# Altium schematic sheet ESP_WROOM_32_V2_SCHEMATIC.SchDoc
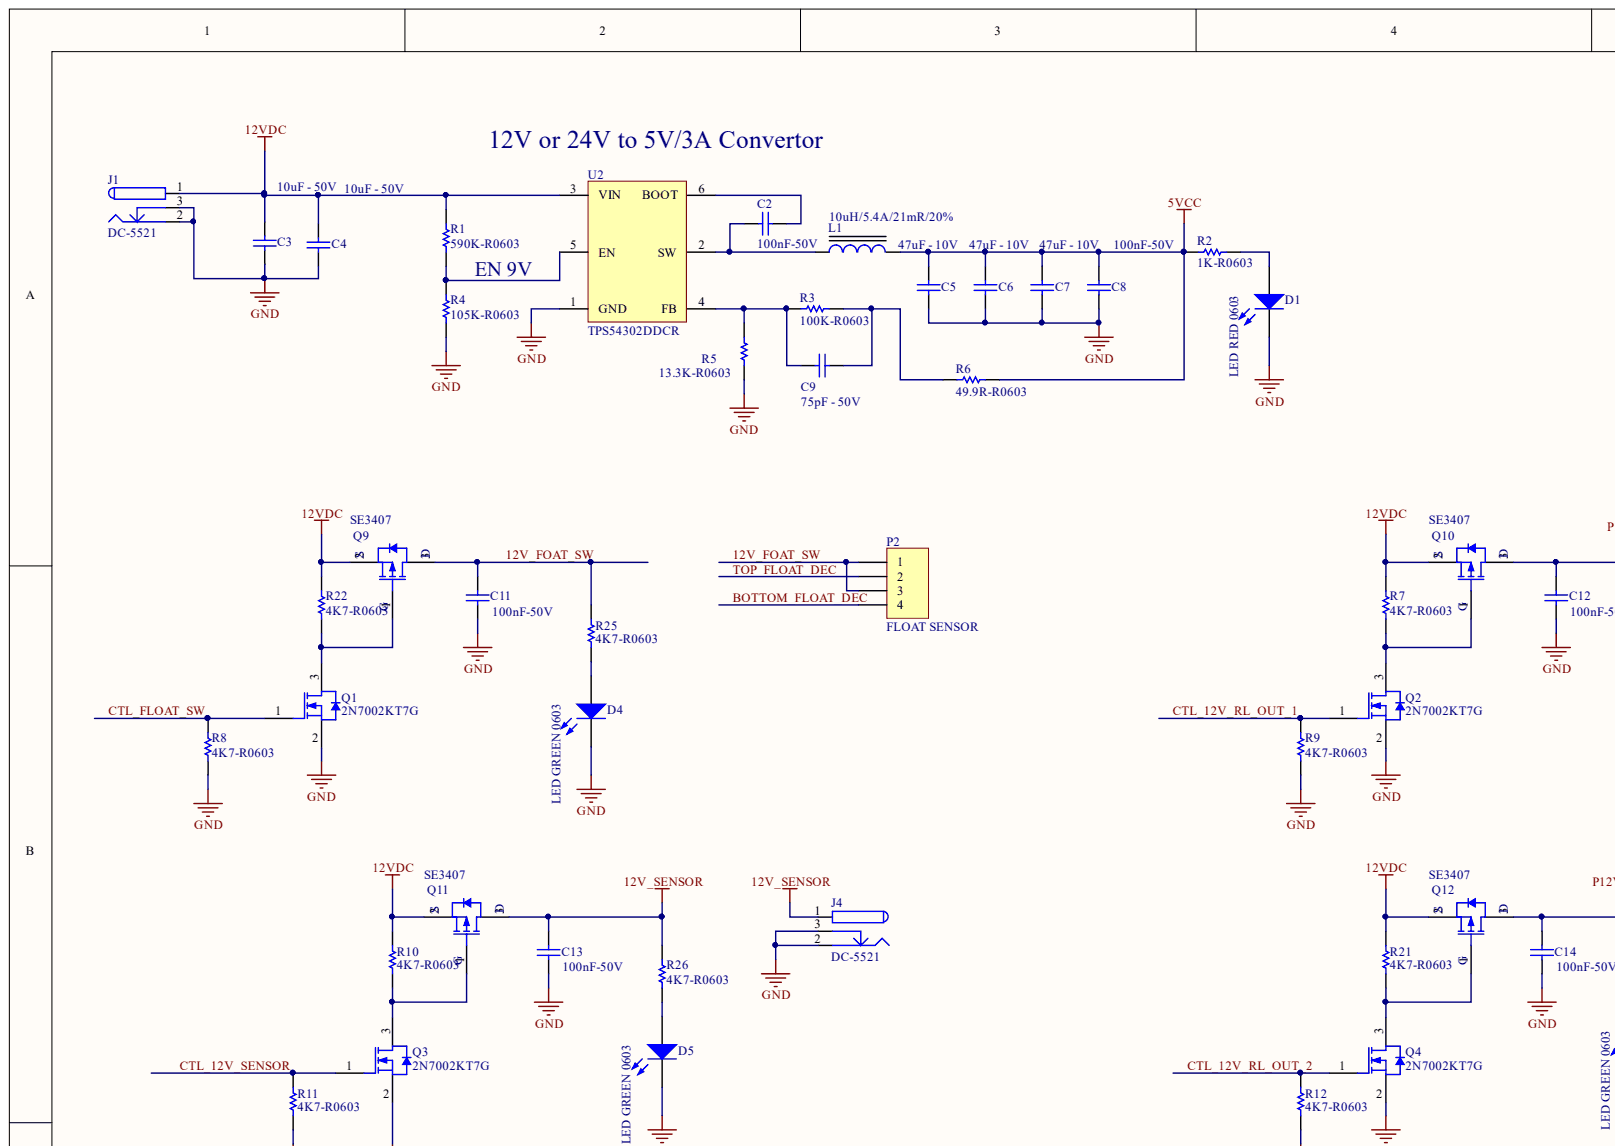
[diagram p0: ESP_WROOM_32_V2_SCHEMATIC.SchDoc, top left]
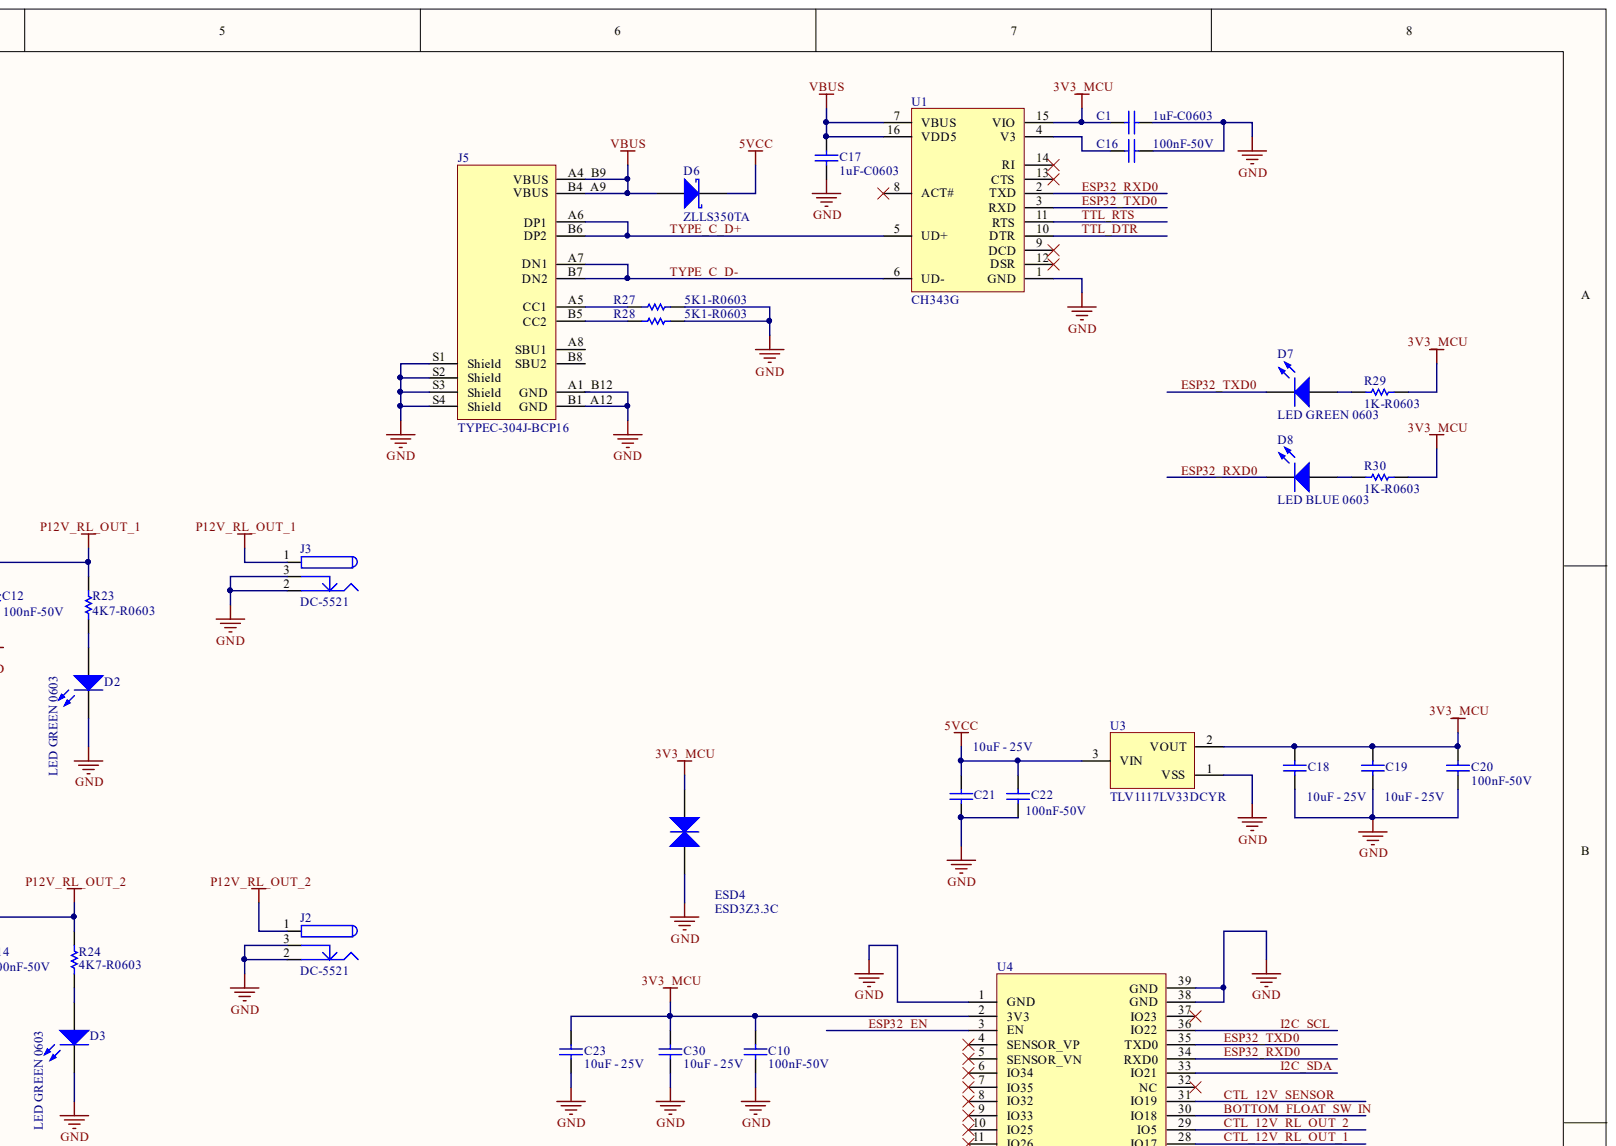
[diagram p1: ESP_WROOM_32_V2_SCHEMATIC.SchDoc, top right]
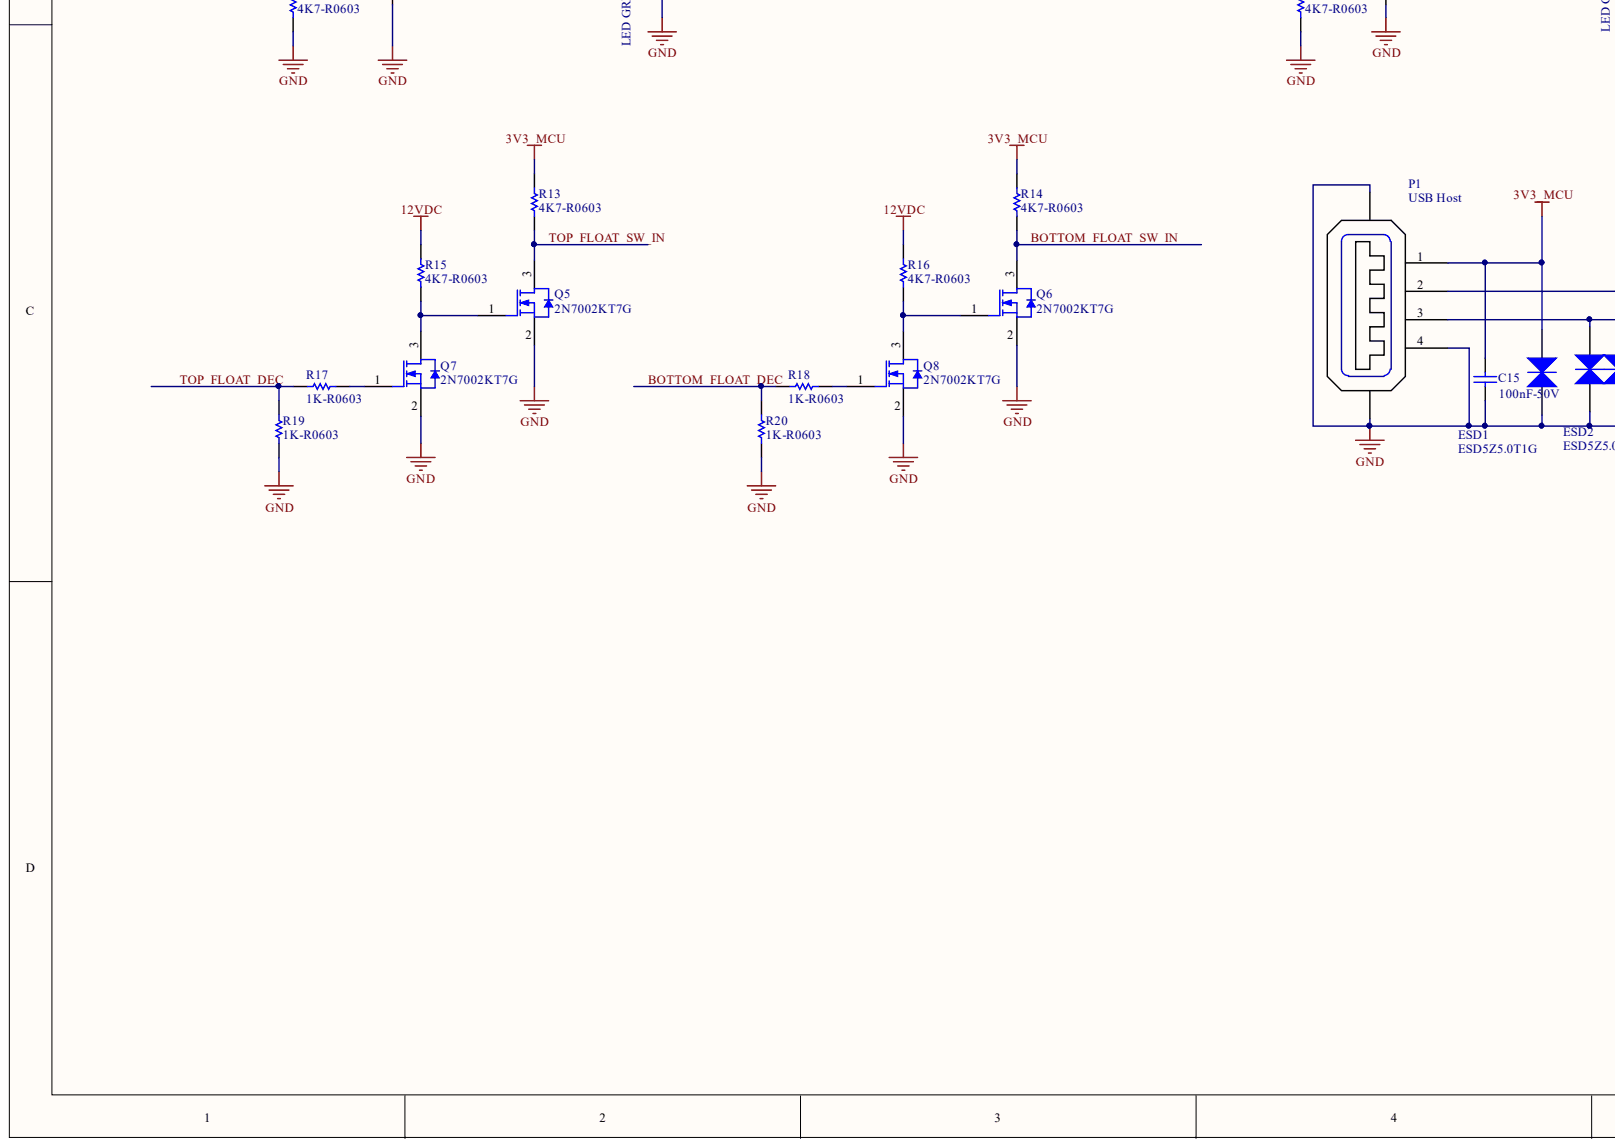
[diagram p2: ESP_WROOM_32_V2_SCHEMATIC.SchDoc, bottom left]
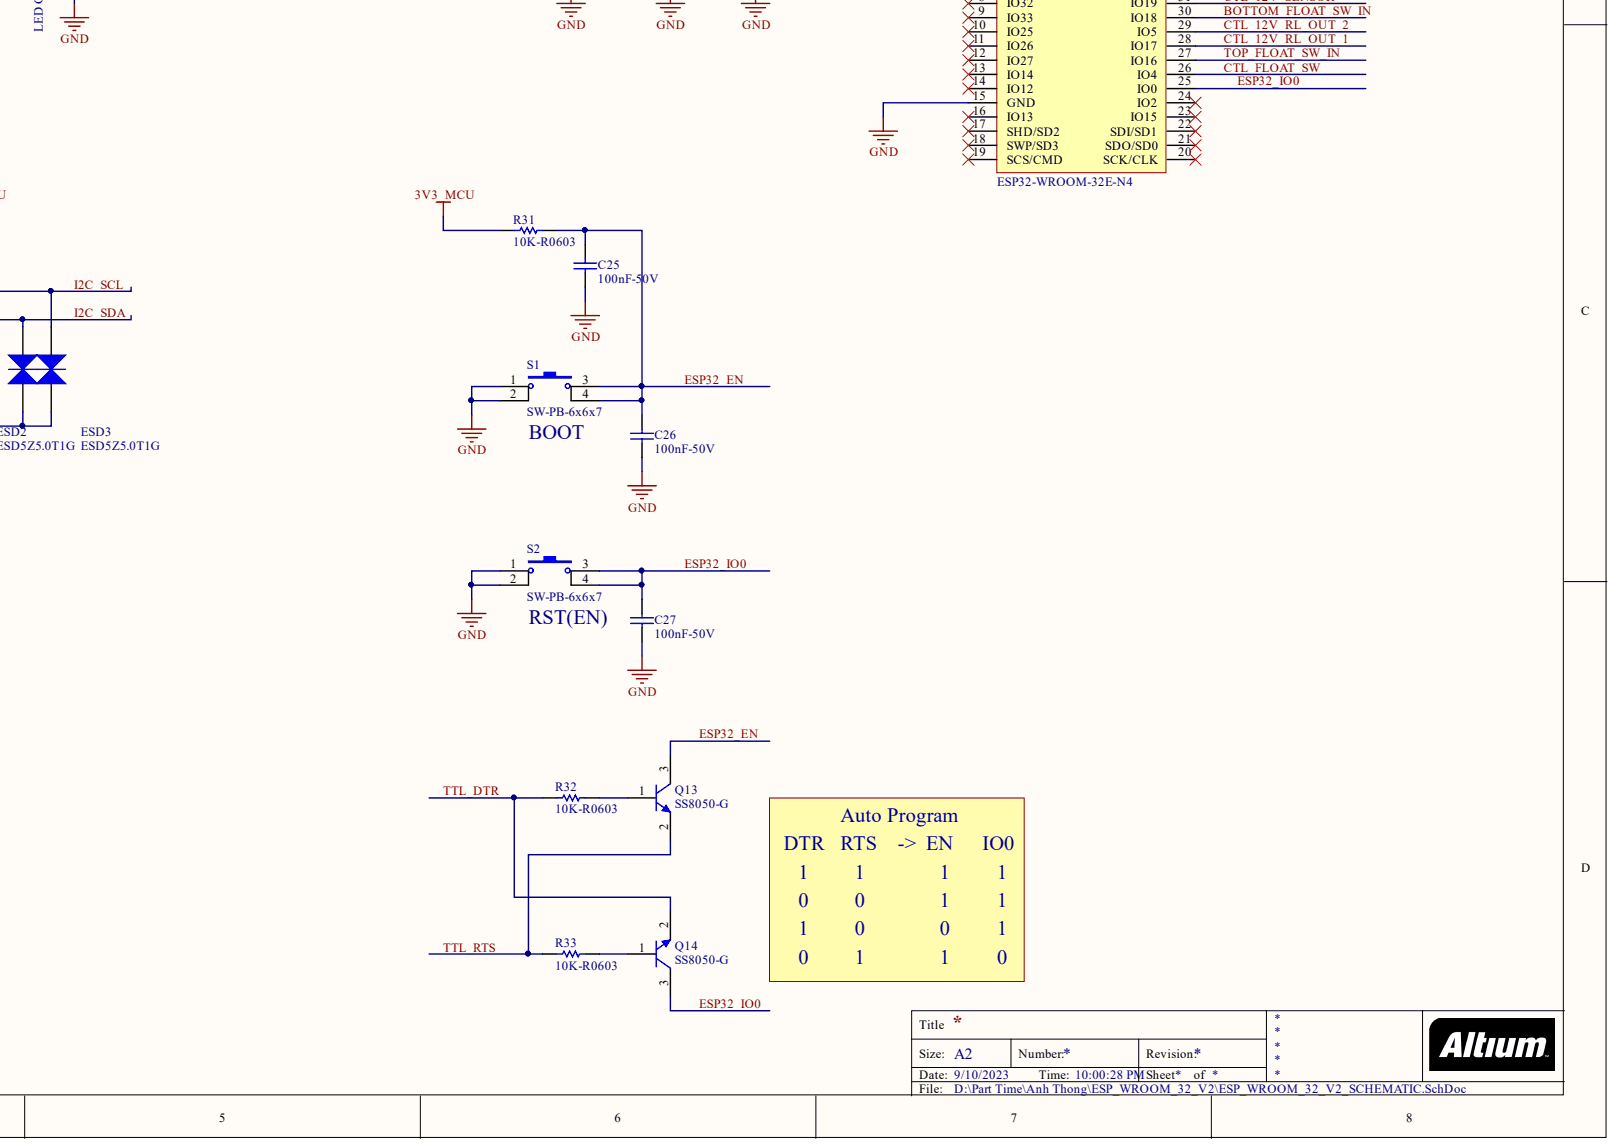
[diagram p3: ESP_WROOM_32_V2_SCHEMATIC.SchDoc, bottom right]

## Parts
C1  1uF-C0603  [C0603]
C2  100nF-50V  [C0603]
C3  10uF - 50V  [C1210]
C4  10uF - 50V  [C1210]
C5  47uF - 10V  [C1210]
C6  47uF - 10V  [C1210]
C7  47uF - 10V  [C1210]
C8  100nF-50V  [C0603]
C9  75pF - 50V  [C0603]
C10  100nF-50V  [C0603]
C11  100nF-50V  [C0603]
C12  100nF-50V  [C0603]
C13  100nF-50V  [C0603]
C14  100nF-50V  [C0603]
C15  100nF-50V  [C0603]
C16  100nF-50V  [C0603]
C17  1uF-C0603  [C0603]
C18  10uF - 25V  [C0603]
C19  10uF - 25V  [C0603]
C20  100nF-50V  [C0603]
C21  10uF - 25V  [C0603]
C22  100nF-50V  [C0603]
C23  10uF - 25V  [C0603]
C25  100nF-50V  [C0603]
C26  100nF-50V  [C0603]
C27  100nF-50V  [C0603]
C30  10uF - 25V  [C0603]
D1  LED RED 0603  [LED0603]
D2  LED GREEN 0603  [LED0603]
D3  LED GREEN 0603  [LED0603]
D4  LED GREEN 0603  [LED0603]
D5  LED GREEN 0603  [LED0603]
D6  ZLLS350TA  [SOD523]
D7  LED GREEN 0603  [LED0603]
D8  LED BLUE 0603  [LED0603]
ESD1  ESD5Z5.0T1G  [SOD523]
ESD2  ESD5Z5.0T1G  [SOD523]
ESD3  ESD5Z5.0T1G  [SOD523]
ESD4  ESD3Z3.3C  [SOD323]
J1  DC-5521  [Jack DC-5521]
J2  DC-5521  [Jack DC-5521]
J3  DC-5521  [Jack DC-5521]
J4  DC-5521  [Jack DC-5521]
J5  TYPEC-304J-BCP16  [TYPEC-304J-BCP16]
L1  10uH/5.4A/21mR/20%  [inductor 12x12]
P1  USB Host  [USB-A-hor]
P2  FLOAT SENSOR  [domino 4pin]
Q1  2N7002KT7G  [SOT23]
Q2  2N7002KT7G  [SOT23]
Q3  2N7002KT7G  [SOT23]
Q4  2N7002KT7G  [SOT23]
Q5  2N7002KT7G  [SOT23]
Q6  2N7002KT7G  [SOT23]
Q7  2N7002KT7G  [SOT23]
Q8  2N7002KT7G  [SOT23]
Q9  SE3407  [SOT23]
Q10  SE3407  [SOT23]
Q11  SE3407  [SOT23]
Q12  SE3407  [SOT23]
Q13  SS8050-G  [SOT-23]
Q14  SS8050-G  [SOT-23]
R1  590K-R0603  [R0603]
R2  1K-R0603  [R0603]
R3  100K-R0603  [R0603]
R4  105K-R0603  [R0603]
R5  13.3K-R0603  [R0603]
R6  49.9R-R0603  [R0603]
R7  4K7-R0603  [R0603]
R8  4K7-R0603  [R0603]
R9  4K7-R0603  [R0603]
R10  4K7-R0603  [R0603]
R11  4K7-R0603  [R0603]
R12  4K7-R0603  [R0603]
R13  4K7-R0603  [R0603]
R14  4K7-R0603  [R0603]
R15  4K7-R0603  [R0603]
R16  4K7-R0603  [R0603]
R17  1K-R0603  [R0603]
R18  1K-R0603  [R0603]
R19  1K-R0603  [R0603]
R20  1K-R0603  [R0603]
R21  4K7-R0603  [R0603]
R22  4K7-R0603  [R0603]
R23  4K7-R0603  [R0603]
R24  4K7-R0603  [R0603]
R25  4K7-R0603  [R0603]
R26  4K7-R0603  [R0603]
R27  5K1-R0603  [R0603]
R28  5K1-R0603  [R0603]
R29  1K-R0603  [R0603]
R30  1K-R0603  [R0603]
R31  10K-R0603  [R0603]
R32  10K-R0603  [R0603]
R33  10K-R0603  [R0603]
S1  SW-PB-6x6x7  [SW4SMD]
S2  SW-PB-6x6x7  [SW4SMD]
U1  CH343G  [SOP16]
U2  TPS54302DDCR  [SOT23-6-THIN]
U3  TLV1117LV33DCYR  [SOT223-3]
U4  ESP32-WROOM-32E-N4  [ESP32-WROOM-32E-N4]

## Nets
3V3_MCU: C1.2 C10.2 C15.2 C18.2 C19.2 C20.2 C23.2 C30.2 ESD1.2 ESD4.2 P1.1 R13.1 R14.1 R29.2 R30.2 R31.2 U1.15(VIO) U3.2 U4.2(3V3)
5VCC: C5.2 C6.2 C7.2 C8.2 C21.2 C22.2 D6.1 L1.2 R2.2 R6.1 U3.3
12V_SENSOR: C13.2 J4.1 Q11.3 R26.1
12VDC: C3.2 C4.2 J1.1 Q9.2 Q10.2 Q11.2 Q12.2 R1.2 R7.1 R10.1 R15.1 R16.1 R21.1 R22.1 U2.3(VIN)
GND: C1.1 C3.1 C4.1 C5.1 C6.1 C7.1 C8.1 C10.1 C11.1 C12.1 C13.1 C14.1 C15.1 C16.1 C17.1 C18.1 C19.1 C20.1 C21.1 C22.1 C23.1 C25.1 C26.1 C27.1 C30.1 D1.2 D2.2 D3.2 D4.2 D5.2 ESD1.1 ESD2.1 ESD3.1 ESD4.1 J1.2 J1.3 J2.2 J2.3 J3.2 J3.3 J4.2 J4.3 J5.A1_B12(GND) J5.B1_A12(GND) J5.S1(Shield) J5.S2(Shield) J5.S3(Shield) J5.S4(Shield) P1.4 P1.MH1 P1.MH2 Q1.2 Q2.2 Q3.2 Q4.2 Q5.2 Q6.2 Q7.2 Q8.2 R4.2 R5.1 R8.2 R9.2 R11.2 R12.2 R19.1 R20.1 R27.2 R28.2 S1.1 S1.2 S2.1 S2.2 U1.1(GND) U2.1(GND) U3.1 U4.1(GND) U4.15(GND) U4.38(GND) U4.39(GND)
P12V_RL_OUT_1: C12.2 J3.1 Q10.3 R23.1
P12V_RL_OUT_2: C14.2 J2.1 Q12.3 R24.1
VBUS: C17.2 D6.2 J5.A4_B9(VBUS) J5.B4_A9(VBUS) U1.7(VBUS) U1.16(VDD5)
12V_FOAT_SW: C11.2 P2.1 P2.3 Q9.3 R25.1
BOTTOM_FLOAT_DEC: P2.4 R18.1 R20.2
BOTTOM_FLOAT_SW_IN: Q6.3 R14.2 U4.30(IO18)
CTL_12V_RL_OUT_1: Q2.1 R9.1 U4.28(IO17)
CTL_12V_RL_OUT_2: Q4.1 R12.1 U4.29(IO5)
CTL_12V_SENSOR: Q3.1 R11.1 U4.31(IO19)
CTL_FLOAT_SW: Q1.1 R8.1 U4.26(IO4)
ESP32_EN: C25.2 C26.2 Q13.3 R31.1 S1.3 S1.4 U4.3(EN)
ESP32_IO0: C27.2 Q14.3 S2.3 S2.4 U4.25(IO0)
ESP32_RXD0: D8.2 U1.2(TXD) U4.34(RXD0)
ESP32_TXD0: D7.2 U1.3(RXD) U4.35(TXD0)
I2C_SCL: ESD3.2 P1.2 U4.36(IO22)
I2C_SDA: ESD2.2 P1.3 U4.33(IO21)
TOP_FLOAT_DEC: P2.2 R17.1 R19.2
TOP_FLOAT_SW_IN: Q5.3 R13.2 U4.27(IO16)
TTL_DTR: Q14.2 R32.2 U1.10(DTR)
TTL_RTS: Q13.2 R33.2 U1.11(RTS)
TYPE_C_D+: J5.A6(DP1) J5.B6(DP2) U1.5(UD+)
TYPE_C_D-: J5.A7(DN1) J5.B7(DN2) U1.6(UD-)
NetC2_1: C2.1 U2.6(BOOT)
NetC2_2: C2.2 L1.1 U2.2(SW)
NetC9_1: C9.1 R3.1 R6.2
NetC9_2: C9.2 R3.2 R5.2 U2.4(FB)
NetC16_2: C16.2 U1.4(V3)
NetD1_1: D1.1 R2.1
NetD2_1: D2.1 R23.2
NetD3_1: D3.1 R24.2
NetD4_1: D4.1 R25.2
NetD5_1: D5.1 R26.2
NetD7_1: D7.1 R29.1
NetD8_1: D8.1 R30.1
NetJ5_A5: J5.A5(CC1) R27.1
NetJ5_B5: J5.B5(CC2) R28.1
NetQ1_3: Q1.3 Q9.1 R22.2
NetQ2_3: Q2.3 Q10.1 R7.2
NetQ3_3: Q3.3 Q11.1 R10.2
NetQ4_3: Q4.3 Q12.1 R21.2
NetQ5_1: Q5.1 Q7.3 R15.2
NetQ6_1: Q6.1 Q8.3 R16.2
NetQ7_1: Q7.1 R17.2
NetQ8_1: Q8.1 R18.2
NetQ13_1: Q13.1 R32.1
NetQ14_1: Q14.1 R33.1
NetR1_1: R1.1 R4.1 U2.5(EN)

Not connected: J5 A8(SBU1) B8(SBU2); U1 8(ACT#) 9(DCD) 12(DSR) 13(CTS) 14(RI); U4 4(SENSOR_VP) 5(SENSOR_VN) 6(IO34) 7(IO35) 8(IO32) 9(IO33) 10(IO25) 11(IO26) 12(IO27) 13(IO14) 14(IO12) 16(IO13) 17(SHD/SD2) 18(SWP/SD3) 19(SCS/CMD) 20(SCK/CLK) 21(SDO/SD0) 22(SDI/SD1) 23(IO15) 24(IO2) 32(NC) 37(IO23)

## Library data of the parts
C1 C17 = 1uF/6.3V/C0603/10%: CAP CER 1UF 50V X7R 0603; Manufacturer: YAGEO; Manufacturer Part Number: CC0603KRX7R9BB105; Component Kind: Standard; Package / Case: 0603 (1608 Metric); Library Name: Manh_Capacitor.SchLib
C2 C8 C10-C16 C20 C22 C25-C27 = Cap: CAP CER 0.1UF 50V X7R 0603; Manufacturer: Yageo; Manufacturer Part Number: CC0603KPX7R9BB104; Component Kind: Standard; Library Name: Library.SchLib; Package / Case: 0603 (1608 Metric); Price: 0.01306; Signal Integrity: Cap; Simulation: CAP
C3 C4 = Cap: CAP CER 10UF 50V X7R 1210; Manufacturer: Murata Electronics; Manufacturer Part Number: GRM32ER71H106KA12L; Component Kind: Standard; Library Name: Library.SchLib; Package / Case: 1210 (3225 Metric); Price: 0.08938; Signal Integrity: Cap; Simulation: CAP
C5-C7 = Cap: CAP CER 47UF 10V X7R 1210; Manufacturer: Murata Electronics; Manufacturer Part Number: GRM32ER71A476ME15L; Component Kind: Standard; Library Name: Library.SchLib; Package / Case: 1210 (3225 Metric); Price: 0.08938; Signal Integrity: Cap; Simulation: CAP
C9 = Cap: CAP CER 75PF 50V C0G/NPO 0603; Manufacturer: AVX Corporation; Manufacturer Part Number: YAGEO; Component Kind: Standard; Library Name: Library.SchLib; Package / Case: 0603 (1608 Metric); Price: 0.08938; Signal Integrity: Cap; Simulation: CAP
C18 C19 C21 = Cap: CAP CERAMIC 10UF 25V X5R 10% SMD; Manufacturer: Murata Electronics; Manufacturer Part Number: GRM188R61E106KA73D; Component Kind: Standard; Library Name: Library.SchLib; Package / Case: 0603 (1608 Metric); Price: 0.08938; Signal Integrity: Cap; Simulation: CAP
C23 C30 = 10uF/25V/C1206/10%: CAP CER 10UF 25V X5R 0603; Manufacturer: Murata Electronics; Manufacturer Part Number: GRM188R61E106KA73D; Component Kind: Standard; Library Name: Manh_Capacitor.SchLib
D1 = LED0: LED RED DIFFUSED 1608 SMD; Manufacturer: Rohm Semiconductor; Manufacturer Part Number: SML-D12U1WT86; Component Kind: Standard; Library Name: Library.SchLib; Package / Case: 0603 (1608 Metric); Price: 0.21; Simulation: LED0
D2-D5 D7 = LED0: LED GREEN DIFFUSED 1608 SMD; Manufacturer: Rohm Semiconductor; Manufacturer Part Number: SML-D12M1WT86; Component Kind: Standard; JLCPCB Part: C2922668; Library Name: Library.SchLib; Package / Case: 0603 (1608 Metric); Price: 0.21; Simulation: LED0
D6 = BAT46WJ 100V/0.25A/SOD323: DIODE SCHOTTKY 40V 380MA SOD523; Manufacturer: Diodes Incorporated; Component Kind: Standard; JLCPCB Part: C139441; Manufacturer Part Number: ZLLS350TA; Package / Case: SC-79, SOD-523; Library Name: Manh_Diode.SchLib
D8 = LED0: LED BLUE DIFFUSED 1608 SMD; Manufacturer: Rohm Semiconductor; Manufacturer Part Number: SMLEN3BC8TT86; Component Kind: Standard; JLCPCB Part: C510296; Library Name: Library.SchLib; Package / Case: 0603 (1608 Metric); Price: 0.21; Simulation: LED0
ESD1-ESD3 = ESD: TVS DIODE 5V 18.6V SOD523; Manufacturer: ON Semiconductor; Component Kind: Standard; Library Name: Library.SchLib; Manufacturer Part Number: ESD5Z5.0T1G; Package / Case: SC-79, SOD-523; Price: 0.22
ESD4 = ESD: SOD-323  ESD Protection Devices; Manufacturer: AnBon; Component Kind: Standard; JLCPCB Part: C397775; Library Name: Library.SchLib; Manufacturer Part Number: ESD3Z3.3C; Package / Case: SOD-323; Price: 0.22
J1-J4 = PWR2.5: CONN PWR JACK 2.1X5.5MM SOLDER; Manufacturer: MPD (Memory Protection Devices); Manufacturer Part Number: EJ503A; Component Kind: Standard; Library Name: Library.SchLib; Link: https://www.thegioiic.com/dc-091-dau-noi-nguon-dc-cai-5521-han-pcb-kim-2-1mm?fbclid=IwAR1kWeW3WmRxXv9ejHsJpmCoIXKBVs4RvQRS1pjhuR6pQwIBb-tK05-6VYY; Package / Case: 2.10mm ID (0.083"), 5.50mm OD (0.217"); Price: 1.21; Signal Integrity: Connector
J5 = TYPEC-304J-BCP16: 5A USB 3.1 1 260℃ Surface Mount 16P Female -40℃~+85℃ Type-C SMD  USB Connectors ROHS; Manufacturer: XUNPU; Component Kind: Standard; JLCPCB Part: C2835315; Library Name: Solar Battery Charger_Lib.SchLib; Manufacturer Part Number: TYPEC-304J-BCP16
L1 = 330uH/0.4A/SDR0805/10%: Power Inductors 10uH-9A-5.4A-21mR ±20% SMD; Manufacturer: Coilcraft; Manufacturer Part Number: XAL5030-332MEB; Component Kind: Standard; JLCPCB Part: C9904; Package / Case: Nonstandard; Size / Dimension: 5.68mm x 5.48mm x 3.1mm); Library Name: Manh_Inductor.SchLib
P1 = USB Host: CONN RCPT TYPEA 4POS R/A; Manufacturer: On Shore Technology Inc.; Manufacturer Part Number: USB-A1SSW6; Component Kind: Standard; JLCPCB Part: C503996; Package / Case: Through Hole, Right Angle; Price: 0.68; Library Name: Tuyens Library for Altium Designer.SchLib
P2 = Domino4: Domino 4-Pin; Component Kind: Standard; LatestRevisionDate: 17-Jul-2002; LatestRevisionNote: Re-released for DXP Platform.; Library Name: Manh_Connector.SchLib; Publisher: Altium Limited
Q1-Q8 = SI2318DS 40V/3A/SOT23: MOSFET N-CH 60V 320MA SOT23-3; Manufacturer: onsemi; Component Kind: Standard; Manufacturer Part Number: 2N7002KT7G; Package / Case: TO-236-3, SC-59, SOT-23-3; Library Name: Manh_Transistor.SchLib
Q9-Q12 = Mosfet P: 30V 4.1A 65mΩ@10V,4.1A 1.4W P Channel SOT-23  MOSFETs; Manufacturer: SINO-IC; Component Kind: Standard; JLCPCB Part: C390576; Library Name: Library.SchLib; Manufacturer Part Number: SE3407; Package / Case: SOT-23
Q13 Q14 = MMBT2222ALT1G: TRANS NPN 25V 1.5A SOT23-3; Manufacturer: Comchip Technology; Component Kind: Standard; JLCPCB Part: C164886; Manufacturer Part Number: SS8050-G; Package / Case: TO-236-3, SC-59, SOT-23-3; Library Name: Manh_Transistor.SchLib
R1 = Res1: RES SMD 590K OHM 1% 1/10W 0603; Manufacturer: Vishay Dale; Manufacturer Part Number: CRCW0603590KFKEA; Component Kind: Standard; Library Name: Miscellaneous Devices.IntLib; Package / Case: 0603 (1608 Metric); Price: 0.10
R2 = Res1: RES SMD 1K OHM 1% 1/10W 0603; Manufacturer: Yageo; Component Kind: Standard; Library Name: Miscellaneous Devices.IntLib; Package / Case: 0603 (1608 Metric); Price: 0.10
R3 = Res1: RES SMD 100K OHM 1% 1/10W 0603; Manufacturer: YAGEO; Manufacturer Part Number: RT0603FRD07100KL; Component Kind: Standard; Library Name: Miscellaneous Devices.IntLib; Package / Case: 0603 (1608 Metric); Price: 0.10
R4 = Res1: RES SMD 105K OHM 1% 1/10W 0603; Manufacturer: Yageo; Manufacturer Part Number: AC0603FR-07105KL; Component Kind: Standard; Library Name: Miscellaneous Devices.IntLib; Package / Case: 0603 (2012 Metric); Price: 0.10; Signal Integrity: Res; Simulation: RESISTOR
R5 = Res1: RES SMD 13.3K OHM 1% 1/10W 0603; Manufacturer: YAGEO; Manufacturer Part Number: RT0603FRE0713K3L; Component Kind: Standard; Library Name: Miscellaneous Devices.IntLib; Package / Case: 0603 (1608 Metric); Price: 0.10
R6 = Res1: RES 49.9 OHM 1% 1/10W 0603; Manufacturer: YAGEO; Manufacturer Part Number: RC0603FR-0749R9L; Component Kind: Standard; Library Name: Miscellaneous Devices.IntLib; Package / Case: 0603 (1608 Metric); Price: 0.10
R7-R16 R21-R26 = Res1: RES SMD 4K7 OHM 1% 1/10W 0603; Manufacturer: Yageo; Component Kind: Standard; Library Name: Miscellaneous Devices.IntLib; Package / Case: 0603 (1608 Metric); Price: 0.10
R17-R20 R29 R30 = Res1: RES 1K OHM 1% 1/10W 0603; Manufacturer: Yageo; Manufacturer Part Number: RC0603FR-131KL; Component Kind: Standard; JLCPCB Part: C116692; Library Name: Miscellaneous Devices.IntLib; Package / Case: 0603 (1608 Metric); Price: 0.10
R27 R28 = Res1: RES 5.1K OHM 1% 1/10W 0603; Manufacturer: Yageo; Manufacturer Part Number: RC0603FR-105K1L; Component Kind: Standard; Library Name: Miscellaneous Devices.IntLib; Package / Case: 0603 (1608 Metric); Price: 0.10
R31-R33 = Res1: RES 10K OHM 1% 1/10W 0603; Manufacturer: Yageo; Manufacturer Part Number: AC0603FR-1310KL; Component Kind: Standard; JLCPCB Part: C116677; Library Name: Miscellaneous Devices.IntLib; Package / Case: 0603 (1608 Metric); Price: 0.10
S1 S2 = SW-PB: 50mA Brick nogging SPST 100MΩ 12V SMD,6x6mm  Tactile Switches; Manufacturer: Hanbo Electronic; Manufacturer Part Number: TS-KG02S; Component Kind: Standard; JLCPCB Part: C410366; Library Name: Solar Battery Charger_Lib.SchLib; Link: https://www.thegioiic.com/nut-nhan-6x6mm-cao-7mm-4-chan-xuyen-lo
U1 = CH343G: SOP-16  USB ICs ROHS; Manufacturer: WCH(Jiangsu Qin Heng); Component Kind: Standard; JLCPCB Part: C2844153; Library Name: Solar Battery Charger_Lib.SchLib; Manufacturer Part Number: CH343G
U2 = TPS54302DDCR: IC REG BUCK ADJ 3A TSOT23-6; Manufacturer: Texas Instruments; Component Kind: Standard; JLCPCB Part: C311983; Library Name: Library.SchLib; Manufacturer Part Number: TPS54302DDCR; Package / Case: SOT-23-6 Thin, TSOT-23-6
U3 = XC6206P332: IC REG LINEAR 3.3V 1A SOT223; Manufacturer Part Number: Texas Instruments; Component Kind: Standard; JLCPCB Part: C15578; Library Name: Sensor Module_Lib.SchLib; Manufacturer: TLV1117LV33DCYR
U4 = ESP32-WROOM-32E-N4: RF TXRX MODULE BT TRACE ANT SMD; Manufacturer: Espressif Systems; Component Kind: Standard; JLCPCB Part: C701341; Library Name: Solar Battery Charger_Lib.SchLib; Manufacturer Part Number: ESP32-WROOM-32E-N4
